# Altium schematic sheet FanModule.SchDoc
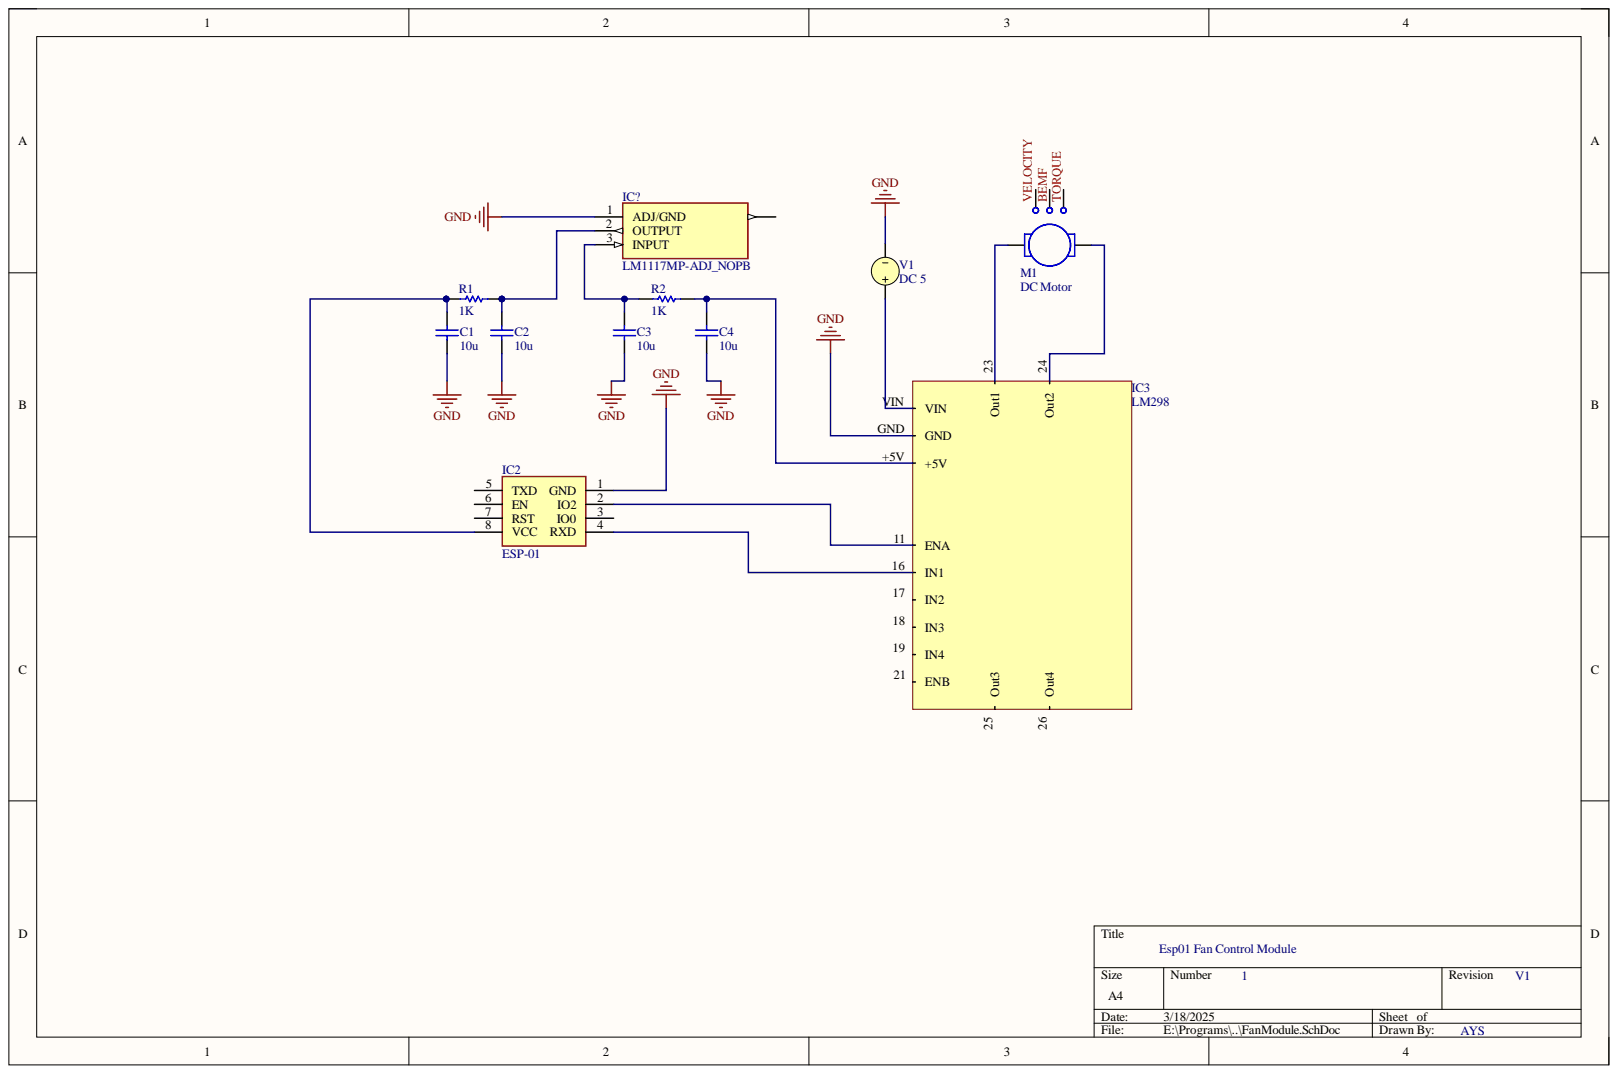
[diagram p0: FanModule.SchDoc]

## Parts
C1  value 10u  (hidden comment: Capacitor)
C2  value 10u  (hidden comment: Capacitor)
C3  value 10u  (hidden comment: Capacitor)
C4  value 10u  (hidden comment: Capacitor)
IC2  ESP-01  [ESP01]
IC3  LM298
IC?  LM1117MP-ADJ_NOPB  [SOT230P700X180-4N]
M1  DC Motor
R1  value 1K  (hidden comment: Resistor)
R2  value 1K  (hidden comment: Resistor)
V1  DC 5  value 5

## Nets
GND: C1.1 C2.1 C3.1 C4.1 IC2.1(GND) IC3.GND IC?.1(ADJ/GND) V1.2
NetC1_2: C1.2 IC2.8(VCC) R1.1
NetC2_2: C2.2 IC?.2(OUTPUT) R1.2
NetC3_2: C3.2 IC?.3(INPUT) R2.1
NetC4_2: C4.2 IC3.+5V R2.2
NetIC2_2: IC2.2(IO2) IC3.11(ENA)
NetIC2_4: IC2.4(RXD) IC3.16(IN1)
NetIC3_23: IC3.23(Out1) M1.1
NetIC3_24: IC3.24(Out2) M1.2
NetIC3_VIN: IC3.VIN V1.1

Not connected: IC2 3(IO0) 5(TXD) 6(EN) 7(RST); IC3 17(IN2) 18(IN3) 19(IN4) 21(ENB) 25(Out3) 26(Out4); IC? 4; M1 3 4 5

## Library data of the parts
C1-C4 = Capacitor: SimulationGenericComponent: True; Component Kind: Standard; Description: Capacitor; Library Name: Simulation Generic Components.IntLib; Simulation: C_Capacitor_Default
IC2 = ESP-01: Integrated Circuit; Manufacturer_Name: Ai-Thinker; Component Kind: Standard; Datasheet Link: https://docs.ai-thinker.com/_media/esp8266/docs/esp-01_product_specification_en.pdf; Library Name: SamacSys.SchLib; Manufacturer_Part_Number: ESP-01
IC3 = LM298: LM298 Motor Driver Module; Component Kind: Standard; Library Name: Schlib1.SchLib
IC? = LM1117MP-ADJ_NOPB: Integrated Circuit; Manufacturer_Name: Texas Instruments; Manufacturer_Part_Number: LM1117MP-ADJ/NOPB; Arrow Part Number: LM1117MP-ADJ/NOPB; Arrow Price/Stock: https://www.arrow.com/en/products/lm1117mp-adjnopb/texas-instruments?utm_currency=USD&region=nac; Component Kind: Standard; Datasheet Link: https://datasheet.datasheetarchive.com/originals/distributors/Datasheets-SFU2/DSASFU100036103.pdf; Height: 1.8mm; Library Name: SamacSys.SchLib; Mouser Part Number: 926-LM1117MP-ADJNOPB; Mouser Price/Stock: https://www.mouser.co.uk/ProductDetail/Texas-Instruments/LM1117MP-ADJ-NOPB?qs=X1J7HmVL2ZHIFGWbJS5wtA%3D%3D
M1 = DC Motor: BM: 0.1M; JM: 250U; KE: 0.05; LA: 1.5M; RA: 0.5; SimulationGenericComponent: True; TL: 0; Component Kind: Standard; Description: DC Motor; KT: 0.05; Library Name: Simulation Generic Components.IntLib; Simulation: DC_MOTOR
R1 R2 = Resistor: SimulationGenericComponent: True; TC1: 0; Component Kind: Standard; Description: Resistor; Library Name: Simulation Generic Components.IntLib; Simulation: R_Resistor_Default; TC2: 0
V1 = VSRC: Voltage Source; AC Magnitude: 1; AC Phase: 0; Note: PCB Footprint - Not required; Component Kind: Standard; LatestRevisionDate: 17-Jul-2002; LatestRevisionNote: Re-released for DXP Platform.; Library Name: Simulation Generic Components.IntLib; PackageReference: Not Applicable; Published: 8-Jun-2000; Simulation: VSRC; Publisher: Altium Limited
